# Altium schematic sheet ControllerCR.SchDoc
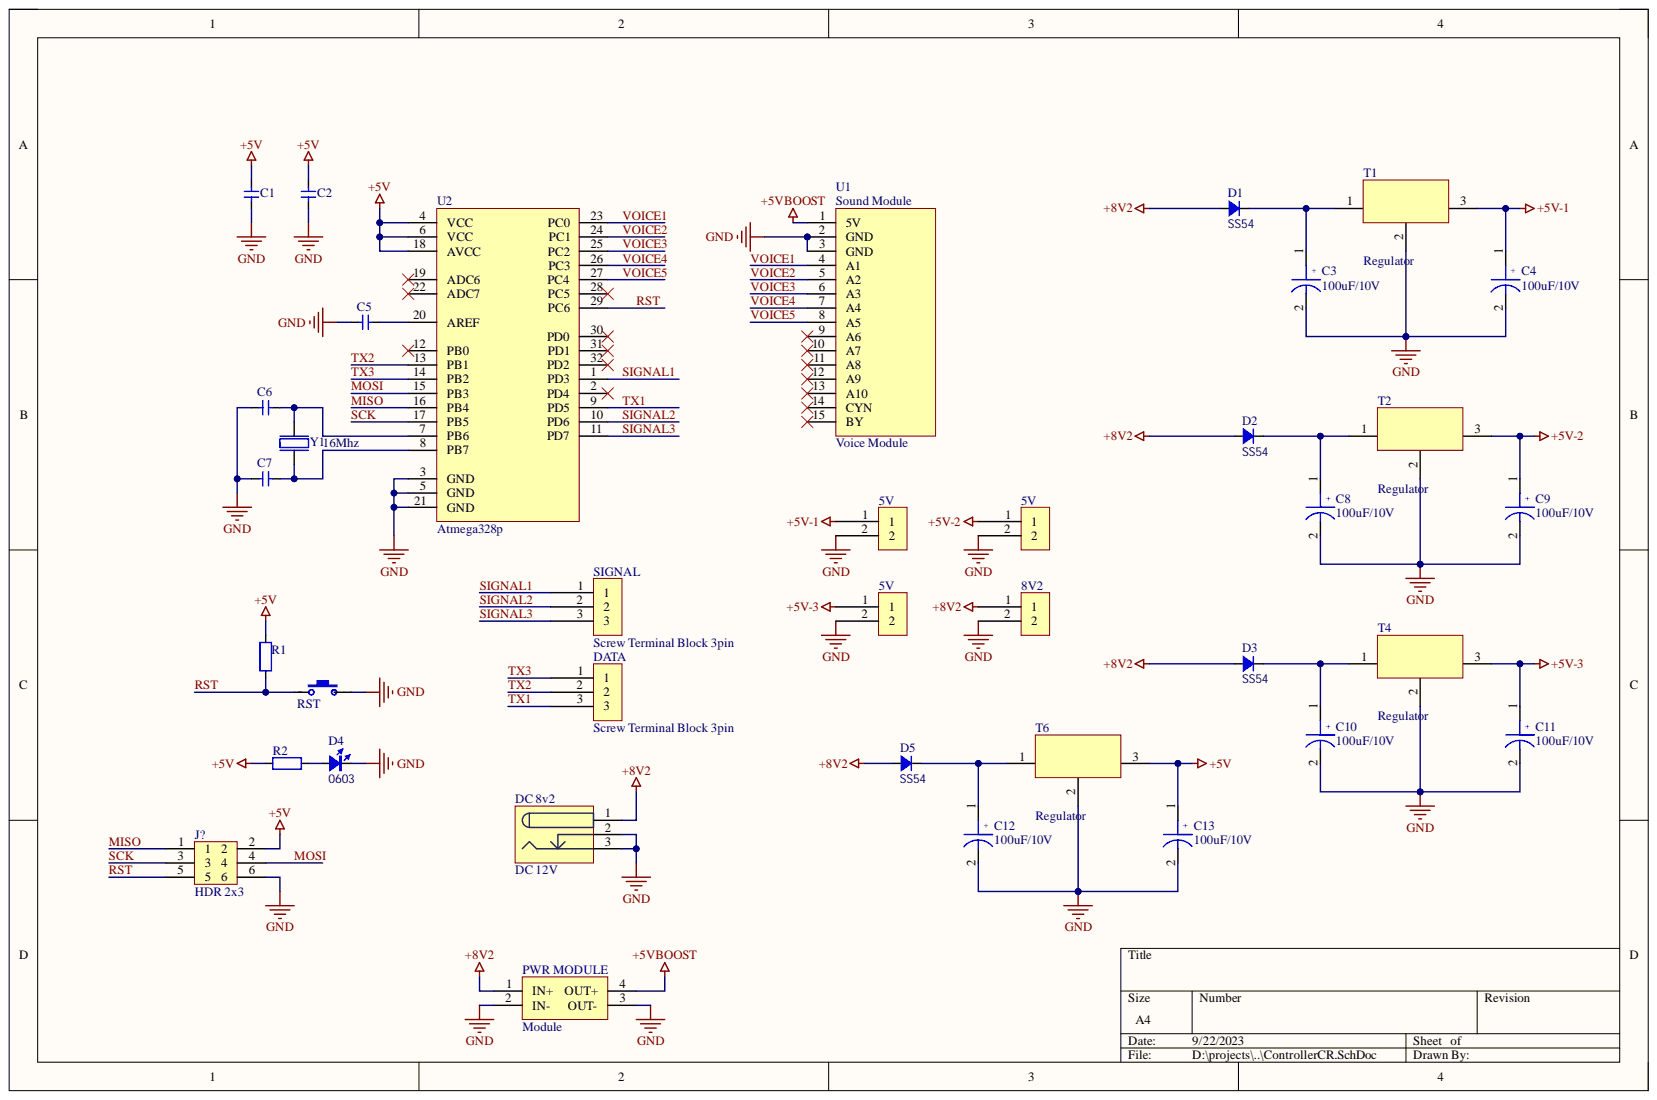
[diagram p0: ControllerCR.SchDoc]

## Parts
5V  Screw Terminal Block 2pin  [screw terminal block 2 pin]  (value not drawn)
5V  Screw Terminal Block 2pin  [screw terminal block 2 pin]  (value not drawn)
5V  Screw Terminal Block 2pin  [screw terminal block 2 pin]  (value not drawn)
8V2  Screw Terminal Block 2pin  [screw terminal block 2 pin]  (value not drawn)
C1  100nf  [CAP_0805]  (value not drawn)
C2  100nf  [CAP_0805]  (value not drawn)
C3  100uF/10V  [Cap Electrolytic Dip]
C4  100uF/10V  [Cap Electrolytic Dip]
C5  100nf  [CAP_0805]  (value not drawn)
C6  22pf  [CAP_0805]  (value not drawn)
C7  22pf  [CAP_0805]  (value not drawn)
C8  100uF/10V  [Cap Electrolytic Dip]
C9  100uF/10V  [Cap Electrolytic Dip]
C10  100uF/10V  [Cap Electrolytic Dip]
C11  100uF/10V  [Cap Electrolytic Dip]
C12  100uF/10V  [Cap Electrolytic Dip]
C13  100uF/10V  [Cap Electrolytic Dip]
D1  SS54  [DO-214AC]
D2  SS54  [DO-214AC]
D3  SS54  [DO-214AC]
D4  Type: 0603  [0603D BLUE]  (hidden comment: Blue SMD)
D5  SS54  [DO-214AC]
DATA  Screw Terminal Block 3pin  [screw terminal block 3 pin]
DC_8v2  DC 12V  [DC Socket 12V]
J?  HDR 2x3  [HDR 2x3 2.54mm]
PWR_MODULE  Module  [LM2596 Module]
R1  R_0805_10K  [RES_0805]  (value not drawn)
R2  R_0805_1K  [RES_0805]  (value not drawn)
RST  SMD  [SMD Tactile Switch]  (value not drawn)
SIGNAL  Screw Terminal Block 3pin  [screw terminal block 3 pin]
T1  Regulator  [7805 regulator]
T2  Regulator  [7805 regulator]
T4  Regulator  [7805 regulator]
T6  Regulator  [7805 regulator]
U1  Voice Module  [Voice Playback]
U2  Atmega328p  [TQFP_32]
Y1  16Mhz  [Crystall]

## Nets
+5V: C1.2 C2.2 C13.1 J?.2 R1.2 R2.2 T6.3 U2.4(VCC) U2.6(VCC) U2.18(AVCC)
+5V-1: 5V.1 C4.1 T1.3
+5V-2: 5V.1 C9.1 T2.3
+5V-3: 5V.1 C11.1 T4.3
+5VBOOST: PWR_MODULE.4(OUT+) U1.1(5V)
+8V2: 8V2.1 D1.1 D2.1 D3.1 D5.1 DC_8v2.1 PWR_MODULE.1(IN+)
GND: 5V.2 8V2.2 C1.1 C2.1 C3.2 C4.2 C5.1 C6.1 C7.1 C8.2 C9.2 C10.2 C11.2 C12.2 C13.2 D4.2 DC_8v2.2 DC_8v2.3 J?.6 PWR_MODULE.2(IN-) PWR_MODULE.3(OUT-) RST.2 T1.2 T2.2 T4.2 T6.2 U1.2(GND) U1.3(GND) U2.3(GND) U2.5(GND) U2.21(GND)
MISO: J?.1 U2.16(PB4)
MOSI: J?.4 U2.15(PB3)
RST: J?.5 R1.1 RST.1 U2.29(PC6)
SCK: J?.3 U2.17(PB5)
SIGNAL1: SIGNAL.1 U2.1(PD3)
SIGNAL2: SIGNAL.2 U2.10(PD6)
SIGNAL3: SIGNAL.3 U2.11(PD7)
TX1: DATA.3 U2.9(PD5)
TX2: DATA.2 U2.13(PB1)
TX3: DATA.1 U2.14(PB2)
VOICE1: U1.4(A1) U2.23(PC0)
VOICE2: U1.5(A2) U2.24(PC1)
VOICE3: U1.6(A3) U2.25(PC2)
VOICE4: U1.7(A4) U2.26(PC3)
VOICE5: U1.8(A5) U2.27(PC4)
NetC3_1: C3.1 D1.2 T1.1
NetC5_2: C5.2 U2.20(AREF)
NetC6_2: C6.2 U2.7(PB6) Y1.1
NetC7_2: C7.2 U2.8(PB7) Y1.2
NetC8_1: C8.1 D2.2 T2.1
NetC10_1: C10.1 D3.2 T4.1
NetC12_1: C12.1 D5.2 T6.1
NetD4_1: D4.1 R2.1

Not connected: U1 9(A6) 10(A7) 11(A8) 12(A9) 13(A10) 14(CYN) 15(BY); U2 2(PD4) 12(PB0) 19(ADC6) 22(ADC7) 28(PC5) 30(PD0) 31(PD1) 32(PD2)

## Library data of the parts
C1 C2 C5 = C_0805_100nf: 100nf/16V 0805 SMD Capacitor; Value: 0.1u; Component Kind: Standard; Library Name: Efence.SchLib
C3 C4 C8-C13 = Cap Electrolytic DIP: CAP ALUM 100UF 20% 10V SMD; Manufacturer: Panasonic Electronic Components; Manufacturer Part Number: EEE-1AA101WR; Lifetime @ Temp.: 1000 Hrs @ 85°C; Polarization: Polar; Ripple Current - High Frequency: 85mA @ 10kHz; Ripple Current - Low Frequency: 50mA @ 120Hz; Surface Mount Land Size: 0.209" L x 0.209" W (5.30mm x 5.30mm); Applications: General Purpose; Category: Capacitors; Component Kind: Standard; ComponentLink1Description: https://industrial.panasonic.com/cdbs/www-data/pdf/RDE0000/ABA0000C1145.pdf; ComponentLink1URL: https://industrial.panasonic.com/cdbs/www-data/pdf/RDE0000/ABA0000C1145.pdf; ESR (Equivalent Series Resistance): -; Height - Seated (Max): 0.213" (5.40mm); Lead Spacing: -; Mounting Type: Surface Mount; Operating Temperature: -40°C ~ 85°C; Package / Case: Radial, Can - SMD; Packaging: Cut Tape (CT); Part Status: Active; Pricing 1: 1=0.31, 10=0.212, 100=0.1272, 500=0.09538 (USD); RoHS: RoHS Compliant; Size / Dimension: 0.197" Dia (5.00mm); Stock 1: 110329; Supplier 1: Digi-Key; Supplier Part Number 1: PCE3867CT-ND; Tolerance: ±20%; Library Name: Laser Gun ESP32.SchLib
C6 C7 = C_0805_22pf: 22pf/16V 0805 SMD Capacitor; Value: 0.1u; Component Kind: Standard; Library Name: Efence.SchLib
D1-D3 D5 = Diode_SMA: Diode; Type: SMA; Component Kind: Standard; Value: SS54; Library Name: Laser Gun 32.SchLib
D4 = LED_0603: LED SMD LED; Value: color?; Component Kind: Standard; Library Name: Efence.SchLib
DC_8v2 = DC_Socket 12V: DC Socket DIP 12V; Component Kind: Standard; Library Name: ControllerCR.SchLib
J? = 67997-206HLF: CONN HEADER 6POS .100 STR 15AU; Manufacturer: Amphenol FCI; Contact Length - Mating: 0.230" (5.84mm); Contact Length - Post: 0.120" (3.05mm); Material Flammability Rating: UL94 V-0; Number of Positions: 6; Number of Positions Loaded: All; Overall Contact Length: 0.450" (11.43mm); Pitch - Mating: 0.100" (2.54mm); Shrouding: Unshrouded; Style: Board to Board; Applications: -; Category: Connectors, Interconnects; Component Kind: Standard; ComponentLink1Description: http://cdn.amphenol-icc.com/media/wysiwyg/files/drawing/67996.pdf; ComponentLink1URL: http://cdn.amphenol-icc.com/media/wysiwyg/files/drawing/67996.pdf; Connector Type: Header; Contact Finish - Mating: Gold or Gold, GXT™; Contact Finish - Post: -; Contact Finish Thickness - Mating: 15µin (0.38µm); Contact Finish Thickness - Post: -; Contact Material: Phosphor Bronze; Contact Shape: Square; Contact Type: Male Pin; Current Rating: -; Fastening Type: Push-Pull; Features: -; Ingress Protection: -; Insulation Color: Black; Insulation Height: 0.100" (2.54mm); Insulation Material: -; Manufacturer Part Number: 67997-206HLF; Mated Stacking Heights: -; Mounting Type: Through Hole; Number of Rows: 2; Operating Temperature: -; Packaging: Bulk; Part Status: Active; Pricing 1: 1=0.31, 10=0.292, 100=0.2046, 500=0.17536, 1000=0.14613, 5000=0.12525, 10000=0.1169 (USD); RoHS: RoHS Compliant; Row Spacing - Mating: 0.100" (2.54mm); Stock 1: 25739; Supplier 1: Digi-Key; Supplier Part Number 1: 609-3234-ND; Termination: Solder; Voltage Rating: -; Library Name: Efence.SchLib
PWR_MODULE = LM2596 Module: LM2596 Step Down Module; Component Kind: Standard; Library Name: ControllerCR.SchLib
R1 = R_0805_10K: 10K/1% 0805 SMD Resistor; Value: 22R; Component Kind: Standard; Library Name: Efence.SchLib
R2 = R_0805_1K: 1K/1% 0805 SMD Resistor; Value: 22R; Component Kind: Standard; Library Name: Efence.SchLib
RST = ButtonTACT: SMD PUSH Button; Component Kind: Standard; Library Name: Efence.SchLib
T1 T2 T4 T6 = 7805: Regulator 12 to 5V; Component Kind: Standard; Library Name: Laser Gun ESP32.SchLib
U2 = Atmega328p SMD: Atmega328P SMD 32Pin's; Component Kind: Standard; Library Name: Efence.SchLib
Y1 = 16Mhz: CRYSTAL 16Mhz SMD; Manufacturer: Abracon LLC; Manufacturer Part Number: ABM7-16.000MHZ-D2Y-T; Frequency Stability: ±30ppm; Frequency Tolerance: ±20ppm; Load Capacitance: 18pF; Operating Mode: Fundamental; Category: Crystals, Oscillators, Resonators; Component Kind: Standard; ComponentLink1Description: http://www.abracon.com/Resonators/abm7.pdf; ComponentLink1URL: http://www.abracon.com/Resonators/abm7.pdf; Height - Seated (Max): 0.055" (1.40mm); Mounting Type: Surface Mount; Operating Temperature: -40°C ~ 85°C; Package / Case: 2-SMD, No Lead; Packaging: Cut Tape (CT); Part Status: Active; Pricing 1: 1=0.72, 10=0.633, 50=0.5912, 100=0.5225, 500=0.495 (USD); Ratings: -; RoHS: RoHS Compliant; Size / Dimension: 0.236" L x 0.138" W (6.00mm x 3.50mm); Stock 1: 16387; Supplier 1: Digi-Key; Supplier Part Number 1: 535-9840-1-ND; Type: MHz Crystal; Library Name: Efence.SchLib
5V 5V 5V 8V2 = Screw Terminal Block 2pin: Component Kind: Standard; Library Name: ControllerCR.SchLib
DATA SIGNAL = Screw Terminal Block 3pin: Component Kind: Standard; Library Name: ControllerCR.SchLib
U1 = Voice Module: Component Kind: Standard; Library Name: ControllerCR.SchLib
